# Altium schematic sheet 3_3V_DIST.SchDoc
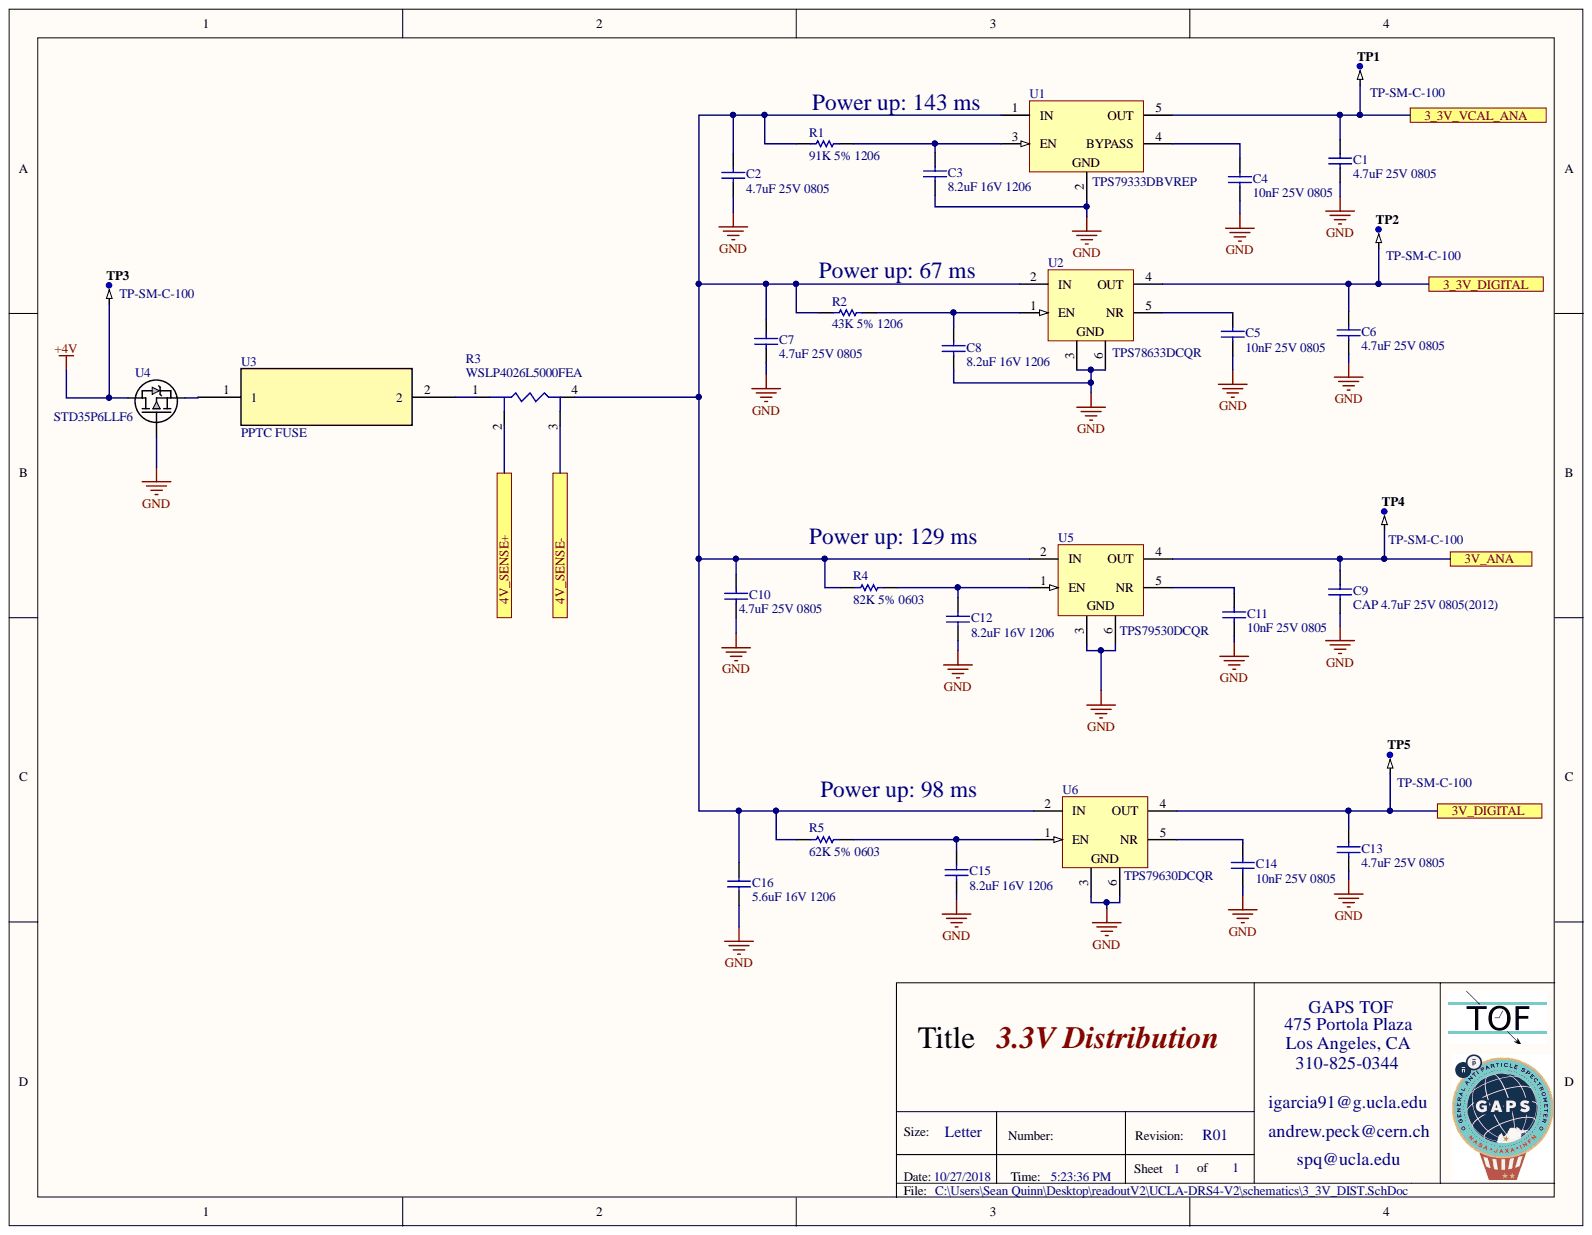
[diagram p0: 3_3V_DIST.SchDoc]

## Parts
C1  4.7uF 25V 0805  value 4.7uF  Case-EIA: 0805  [CAPC0805(2012)145_L]
C2  4.7uF 25V 0805  value 4.7uF  [CAPC0805(2012)145_L]
C3  8.2uF 16V 1206  value 8.2uF  [CAPC1206(3216)190_N]
C4  10nF 25V 0805  value 10nF  [CAPC0805(2012)145_M]
C5  10nF 25V 0805  value 10nF  [CAPC0805(2012)145_M]
C6  4.7uF 25V 0805  value 4.7uF  Case-EIA: 0805  [CAPC0805(2012)145_L]
C7  4.7uF 25V 0805  value 4.7uF  [CAPC0805(2012)145_L]
C8  8.2uF 16V 1206  value 8.2uF  [CAPC1206(3216)190_N]
C9  CAP 4.7uF 25V 0805(2012)  value 4.7uF  Case-EIA: 0805  [CAPC0805(2012)145_L]
C10  4.7uF 25V 0805  value 4.7uF  [CAPC0805(2012)145_L]
C11  10nF 25V 0805  value 10nF  [CAPC0805(2012)145_M]
C12  8.2uF 16V 1206  value 8.2uF  [CAPC1206(3216)190_N]
C13  4.7uF 25V 0805  value 4.7uF  Case-EIA: 0805  [CAPC0805(2012)145_L]
C14  10nF 25V 0805  value 10nF  [CAPC0805(2012)145_M]
C15  8.2uF 16V 1206  value 8.2uF  [CAPC1206(3216)190_N]
C16  5.6uF 16V 1206  value 5.6uF  [CAPC1206(3216)190_N]
R1  91K 5% 1206  Case-EIA: 1206  [RESC1206(3216)_M]
R2  43K 5% 1206  Case-EIA: 1206  [RESC1206(3216)_N]
R3  WSLP4026L5000FEA
R4  82K 5% 0603  Case-EIA: 0603  [RESC0603(1608)_M]
R5  62K 5% 0603  Case-EIA: 0603  [RESC0603(1608)_L]
TP1  TP-SM-C-100  [TP-SM-C-100]  (no BOM)
TP2  TP-SM-C-100  [TP-SM-C-100]  (no BOM)
TP3  TP-SM-C-100  [TP-SM-C-100]  (no BOM)
TP4  TP-SM-C-100  [TP-SM-C-100]  (no BOM)
TP5  TP-SM-C-100  [TP-SM-C-100]  (no BOM)
U1  TPS79333DBVREP  [DBV0005A_M]
U2  TPS78633DCQR  [DCQ0006A_L]
U3  PPTC FUSE  [0ZCF]
U4  STD35P6LLF6  [DPAK]
U5  TPS79530DCQR  [DCQ0006A_L]
U6  TPS79630DCQR  [DCQ0006A_L]

## Ports
3_3V_DIGITAL, 3_3V_VCAL_ANA, 3V_ANA, 3V_DIGITAL, 4V_SENSE+, 4V_SENSE-

## Nets
+4V: TP3.1 U4.2
GND: C1.1 C2.1 C3.1 C4.1 C5.1 C6.1 C7.1 C8.1 C9.1 C10.1 C11.1 C12.1 C13.1 C14.1 C15.1 C16.1 U1.2 U2.3 U2.6 U4.1 U5.3 U5.6 U6.3 U6.6
3_3V_DIGITAL: C6.2 TP2.1 U2.4(OUT) ; port 3_3V_DIGITAL
3_3V_VCAL_ANA: C1.2 TP1.1 U1.5(OUT) ; port 3_3V_VCAL_ANA
3V_ANA: C9.2 TP4.1 U5.4(OUT) ; port 3V_ANA
3V_DIGITAL: C13.2 TP5.1 U6.4(OUT) ; port 3V_DIGITAL
NetC2_2: C2.2 C7.2 C10.2 C16.2 R1.1 R2.1 R3.4 R4.1 R5.1 U1.1(IN) U2.2(IN) U5.2(IN) U6.2(IN)
NetC3_2: C3.2 R1.2 U1.3(EN)
NetC4_2: C4.2 U1.4(BYPASS)
NetC5_2: C5.2 U2.5(NR)
NetC8_2: C8.2 R2.2 U2.1(EN)
NetC11_2: C11.2 U5.5(NR)
NetC12_2: C12.2 R4.2 U5.1(EN)
NetC14_2: C14.2 U6.5(NR)
NetC15_2: C15.2 R5.2 U6.1(EN)
NetR3_1: R3.1 U3.2
NetR3_2: R3.2
NetR3_3: R3.3
NetU3_1: U3.1 U4.3

## Library data of the parts
C1 C6 C9 C13 = CMP-1036-04895-1: CAP 4.7uF 25V ±10% 0805 (2012 Metric) Thickness 1.45mm SMD; Case-Metric: 2012; Tolerance: Â±10%; Component Kind: Standard; Library Name: Altium Content Vault; Max Thickness: 1.45 mm; Rated Voltage: 25 V; Technology: SMT
C2 C7 C10 = CMP-1036-04895-1: CAP 4.7uF 25V ±10% 0805 (2012 Metric) Thickness 1.45mm SMD; Case-EIA: 0805; Case-Metric: 2012; Tolerance: Â±10%; Component Kind: Standard; Library Name: Altium Content Vault; Max Thickness: 1.45 mm; Rated Voltage: 25 V; Technology: SMT
C3 C8 C12 C15 = CMP-1037-04959-1: CAP 8.2uF 16V ±20% 1206 (3216 Metric) Thickness 1.9mm SMD; Case-EIA: 1206; Case-Metric: 3216; Tolerance: Â±20%; Component Kind: Standard; Library Name: Altium Content Vault; Max Thickness: 1.9 mm; Rated Voltage: 16 V; Technology: SMT
C4 C5 C11 C14 = CMP-1036-03831-1: CAP 10nF 25V ±10% 0805 (2012 Metric) Thickness 1.45mm SMD; Case-EIA: 0805; Case-Metric: 2012; Tolerance: Â±10%; Component Kind: Standard; Library Name: Altium Content Vault; Max Thickness: 1.45 mm; Rated Voltage: 25 V; Technology: SMT
C16 = CMP-1037-04932-1: CAP 5.6uF 16V ±10% 1206 (3216 Metric) Thickness 1.9mm SMD; Case-EIA: 1206; Case-Metric: 3216; Tolerance: Â±10%; Component Kind: Standard; Library Name: Altium Content Vault; Max Thickness: 1.9 mm; Rated Voltage: 16 V; Technology: SMT
R1 = CMP-1014-00121-1: 91K 0.25W 5% 1206 (3216 Metric)  SMD; Case-Metric: 3216; Value: 91000.00 Ohm; Component Kind: Standard; Library Name: Altium Content Vault; Power: 0.25 W; Technology: SMT; Tolerance: 5 %
R2 = CMP-1014-00113-1: 43K 0.25W 5% 1206 (3216 Metric)  SMD; Case-Metric: 3216; Value: 43000.00 Ohm; Component Kind: Standard; Library Name: Altium Content Vault; Power: 0.25 W; Technology: SMT; Tolerance: 5 %
R3 = WSLP4026L5000FEA: Current Sense Resistors - SMD 7watt .0005ohm 1%; Component Kind: Standard; Library Name: WSLP4026L5000FEA.IntLib
R4 = CMP-1012-00120-1: 82K 0.1W 5% 0603 (1608 Metric)  SMD; Case-Metric: 1608; Value: 82000.00 Ohm; Component Kind: Standard; Library Name: Altium Content Vault; Power: 0.1 W; Technology: SMT; Tolerance: 5 %
R5 = CMP-1012-00117-1: 62K 0.1W 5% 0603 (1608 Metric)  SMD; Case-Metric: 1608; Value: 62000.00 Ohm; Component Kind: Standard; Library Name: Altium Content Vault; Power: 0.1 W; Technology: SMT; Tolerance: 5 %
TP1-TP5 = CMP-0484-00002-2: Test Point, Surface Mount, Circular, Pad Diameter 1 mm; Manufacturer: No Vendor; Library Name: Altium Content Vault; PartNumber: TP-SM-C-100
U1 = CMP-0394-00316-2: Single Output Fast RF LDO, 200 mA, Fixed 3.3 V Output, 2.7 to 5.5 V Input, 5-pin SOT-23 (DBV), -40 to 125 degC, Green (RoHS & no Sb/Br); Manufacturer: Texas Instruments; Accuracy(%): 2; Fixed Output Options(V): 3.3; Iout(Max)(A): 0.2; Rating: HiRel Enhanced Product; SubFamily: Single Channel LDO; Vdo(Typ)(mV): 102; Vin(Max)(V): 5.5; Vin(Min)(V): 2.7; Code_JEDEC: MO-178; Component Kind: Standard; ComponentLink1Description: Manufacturer URL; ComponentLink1URL: http://www.ti.com/; ComponentLink2Description: Datasheet; ComponentLink2URL: http://www.ti.com/general/docs/lit/getliterature.tsp?genericPartNumber=TPS79333-EP&fileType=pdf; ComponentLink3Description: Package Specification; ComponentLink3URL: http://www.ti.com/litv/pdf/mpds018i; DatasheetVersion: SGLS163B; Eco-Plan: Green (RoHS & no Sb/Br); Iq(Typ)(mA): 0.2; Library Name: TPS79333DBVREP.IntLib; Mounting Technology: Surface Mount; PackageDescription: 5-Pin Plastic Small Outline, Body 1.60 Ãƒâ€” 2.90 mm, Pitch 0.95 mm; PackageReference: DBV0005A; PackageVersion: 4073253-4/K; PartNumber: TPS79333DBVREP; Vout Adj(Max)(V): 3.3; Vout Adj(Min)(V): 3.3
U2 = CMP-0395-00353-2: Single Output High PSRR LDO, 1.5 A, Fixed 3.3 V Output, 2.7 to 5.5 V Input, 6-pin SOT-223 (DCQ), -40 to 125 degC, Green (RoHS & no Sb/Br); Manufacturer: Texas Instruments; Accuracy(%): 3; Fixed Output Options(V): 3.3; Iout(Max)(A): 1.5; Iq(Typ)(mA): 0.02; SubFamily: Single Channel LDO; Vdo(Typ)(mV): 340; Vin(Max)(V): 5.5; Vin(Min)(V): 2.7; Component Kind: Standard; ComponentLink1Description: Manufacturer URL; ComponentLink1URL: http://www.ti.com/; ComponentLink2Description: Datasheet; ComponentLink2URL: http://www.ti.com/general/docs/lit/getliterature.tsp?genericPartNumber=TPS78633&fileType=pdf; ComponentLink3Description: Package Specification; ComponentLink3URL: http://www.ti.com/litv/pdf/mpds098c; DatasheetVersion: SLVS389L; Eco-Plan: Green (RoHS & no Sb/Br); Library Name: TPS78633DCQR.IntLib; Mounting Technology: Surface Mount; PackageDescription: 6-Pin Plastic Small Outline, Body 3.5 x 6.5 mm, Pitch 1.27 mm; PackageReference: DCQ0006A; PackageVersion: 4202109/D; PartNumber: TPS78633DCQR; Vout Adj(Max)(V): 3.3; Vout Adj(Min)(V): 3.3
U3 = 0ZCF0250FF2C: Resettable Fuses - PPTC; Built_By: EMA_UL_Team; C: Copyright (C) 2016 Accelerated Designs. All rights reserved; Vendor: Belfuse; Component Kind: Standard; Library Name: 0ZCF0250FF2C.IntLib; Manufacturer_Part_Number: 0ZCF0250FF2C; RefDes: RefDes; Type: DEV; Value: Value
U4 = STD35P6LLF6: MOSFET P-channel 60 V, 0.025 Ohm typ., 35 A STripFET F6 Power MOSFET in a DPAK package; Manufacturer_Part_Number: STD35NF06T4; Built_By: EMA_UL_Team; C: Copyright (C) 2015 Accelerated Designs. All rights reserved; Vendor: STMicroelectronics; Component Kind: Standard; Library Name: STD35P6LLF6.IntLib; RefDes: RefDes; Type: DEV; Value: Value
U5 = CMP-0395-00302-2: Single Output High PSRR LDO, 500 mA, Fixed 3 V Output, 2.7 to 5.5 V Input, 6-pin SOT-223 (DCQ), -40 to 125 degC, Green (RoHS & no Sb/Br); Manufacturer: Texas Instruments; Accuracy(%): 2; Fixed Output Options(V): 3; Iout(Max)(A): 0.5; Iq(Typ)(mA): 0.26; Rating: Catalog; SubFamily: Single Channel LDO; Vdo(Typ)(mV): 110; Vin(Max)(V): 5.5; Vin(Min)(V): 2.7; Component Kind: Standard; ComponentLink1Description: Manufacturer URL; ComponentLink1URL: http://www.ti.com/; ComponentLink2Description: Datasheet; ComponentLink2URL: http://www.ti.com/general/docs/lit/getliterature.tsp?genericPartNumber=TPS79530&fileType=pdf; ComponentLink3Description: Package Specification; ComponentLink3URL: http://www.ti.com/litv/pdf/mpds098c; DatasheetVersion: SLVS350H; Eco-Plan: Green (RoHS & no Sb/Br); Library Name: TPS79530DCQR.IntLib; Mounting Technology: Surface Mount; PackageDescription: 6-Pin Plastic Small Outline, Body 3.5 x 6.5 mm, Pitch 1.27 mm; PackageReference: DCQ0006A; PackageVersion: 4202109/D; PartNumber: TPS79530DCQR; Vout Adj(Max)(V): 3; Vout Adj(Min)(V): 3
U6 = CMP-0395-00336-2: Single Output High PSRR LDO, 1 A, Fixed 3 V Output, 2.7 to 5.5 V Input, 6-pin SOT-223 (DCQ), -40 to 125 degC, Green (RoHS & no Sb/Br); Manufacturer: Texas Instruments; Accuracy(%): 2; Fixed Output Options(V): 3; Iout(Max)(A): 1; Iq(Typ)(mA): 0.26; SubFamily: Single Channel LDO; Vdo(Typ)(mV): 250; Vin(Max)(V): 5.5; Vin(Min)(V): 2.7; Component Kind: Standard; ComponentLink1Description: Manufacturer URL; ComponentLink1URL: http://www.ti.com/; ComponentLink2Description: Datasheet; ComponentLink2URL: http://www.ti.com/general/docs/lit/getliterature.tsp?genericPartNumber=TPS79630&fileType=pdf; ComponentLink3Description: Package Specification; ComponentLink3URL: http://www.ti.com/litv/pdf/mpds098c; DatasheetVersion: SLVS351N; Eco-Plan: Green (RoHS & no Sb/Br); Library Name: TPS79630DCQR.IntLib; Mounting Technology: Surface Mount; PackageDescription: 6-Pin Plastic Small Outline, Body 3.5 x 6.5 mm, Pitch 1.27 mm; PackageReference: DCQ0006A; PackageVersion: 4202109/D; PartNumber: TPS79630DCQR; Vout Adj(Max)(V): 3; Vout Adj(Min)(V): 3
